# Altium schematic sheet MAX485.SchDoc(MAX485)
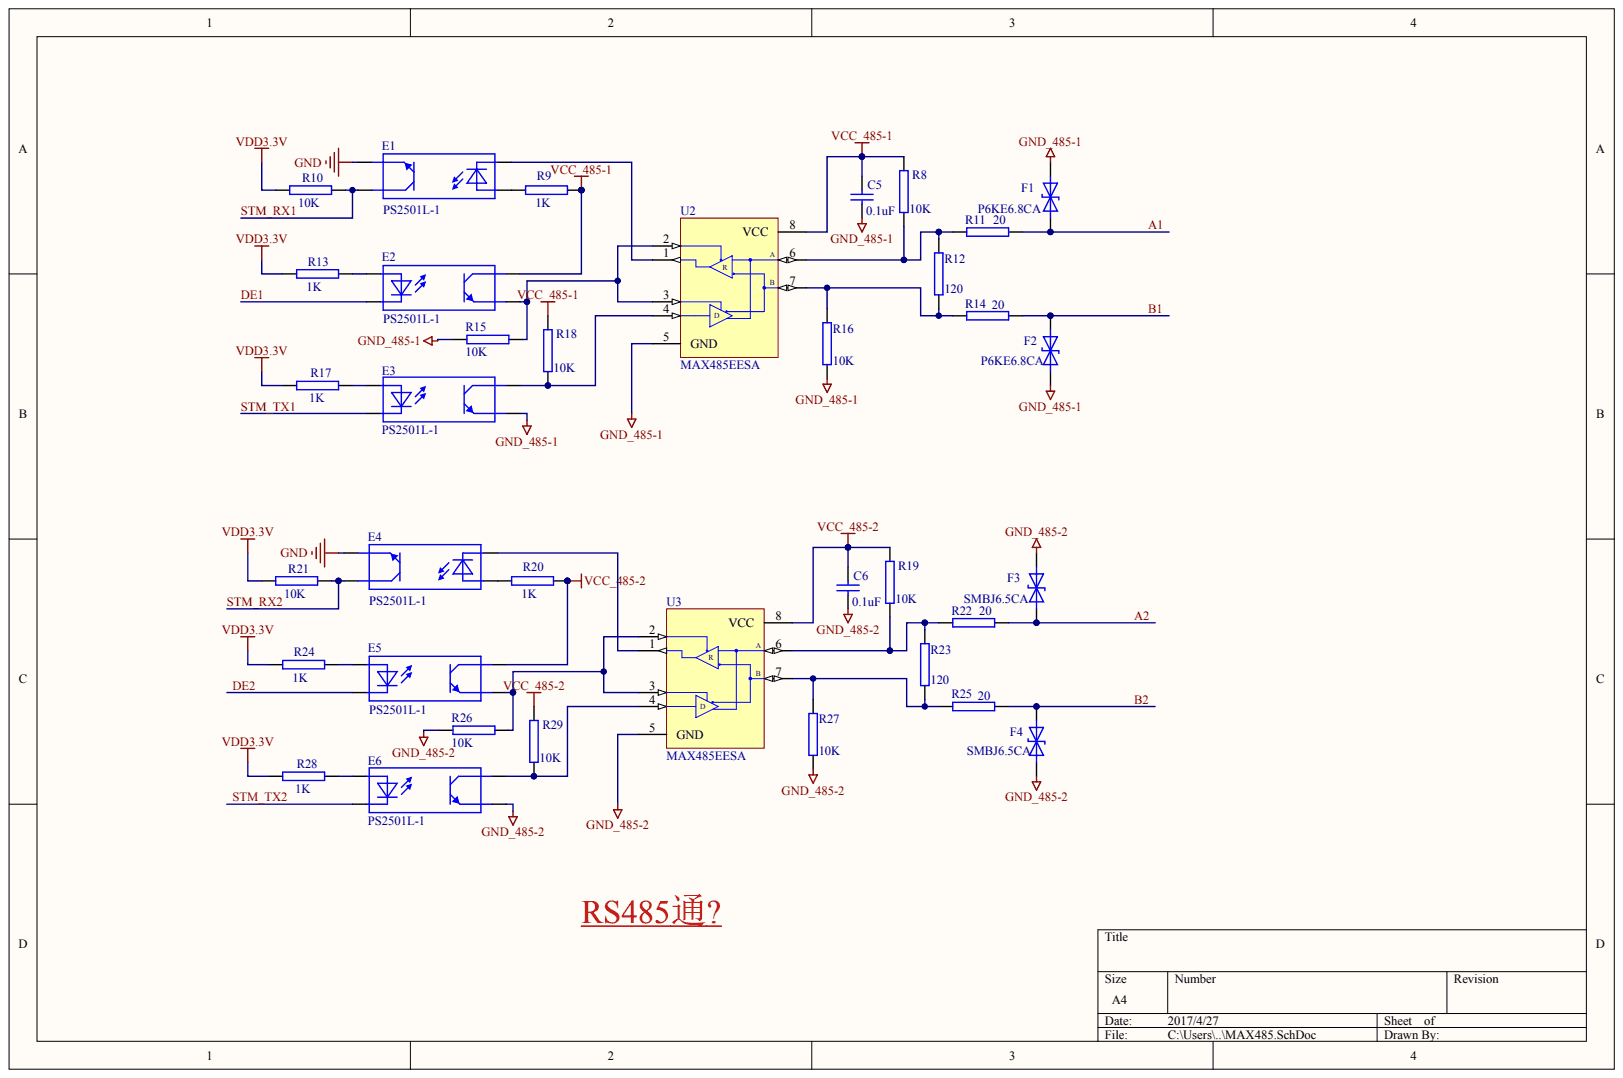
[diagram p0: MAX485.SchDoc]

## Parts
C5  value 0.1uF  (hidden comment: Cap)
C6  value 0.1uF  (hidden comment: Cap)
E1  PS2501L-1
E2  PS2501L-1
E3  PS2501L-1
E4  PS2501L-1
E5  PS2501L-1
E6  PS2501L-1
F1  P6KE6.8CA
F2  P6KE6.8CA
F3  SMBJ6.5CA
F4  SMBJ6.5CA
R8  10K
R9  1K
R10  10K
R11  20
R12  120
R13  1K
R14  20
R15  10K
R16  10K
R17  1K
R18  10K
R19  10K
R20  1K
R21  10K
R22  20
R23  120
R24  1K
R25  20
R26  10K
R27  10K
R28  1K
R29  10K
U2  MAX485EESA
U3  MAX485EESA

## Nets
GND: E1.3 E4.3
GND_485-1: C5.1 E3.3 F1.1 F2.2 R15.2 R16.1 U2.5(GND)
GND_485-2: C6.1 E6.3 F3.1 F4.2 R26.2 R27.1 U3.5(GND)
VCC_485-1: C5.2 E2.4 R8.2 R9.1 R18.2 U2.8(VCC)
VCC_485-2: C6.2 E5.4 R19.2 R20.1 R29.2 U3.8(VCC)
VDD3.3V: R10.2 R13.2 R17.2 R21.2 R24.2 R28.2
A1: F1.2 R11.2
A2: F3.2 R22.2
B1: F2.1 R14.2
B2: F4.1 R25.2
DE1: E2.2
DE2: E5.2
STM_RX1: E1.4 R10.1
STM_RX2: E4.4 R21.1
STM_TX1: E3.2
STM_TX2: E6.2
NetE1_1: E1.1 R9.2
NetE1_2: E1.2 U2.1
NetE2_1: E2.1 R13.1
NetE2_3: E2.3 R15.1 U2.2 U2.3
NetE3_1: E3.1 R17.1
NetE3_4: E3.4 R18.1 U2.4
NetE4_1: E4.1 R20.2
NetE4_2: E4.2 U3.1
NetE5_1: E5.1 R24.1
NetE5_3: E5.3 R26.1 U3.2 U3.3
NetE6_1: E6.1 R28.1
NetE6_4: E6.4 R29.1 U3.4
NetR8_1: R8.1 R11.1 R12.2 U2.6
NetR12_1: R12.1 R14.1 R16.2 U2.7
NetR19_1: R19.1 R22.1 R23.2 U3.6
NetR23_1: R23.1 R25.1 R27.2 U3.7

## Library data of the parts
U2 U3 = (no symbol name): Code - JEDEC: MS-012-AA; Code - IPC: SO8; Published: 16-Jan-2001; Revision: 17-Jul-2002: Re-released for DXP Platform.; Datasheet: Latest Revision: Feb-1996; Package Reference: NSO8; Publisher: Altium Limited
C5 C6 E1-E6 F1-F4 R8-R29 = (no symbol name)
  C5 C6: Published: 8-Jun-2000; LatestRevisionDate: 17-Jul-2002; LatestRevisionNote: Re-released for DXP Platform.; Publisher: Altium Limited
